# Altium schematic sheet IO_PWR.SchDoc(IO_PWR)
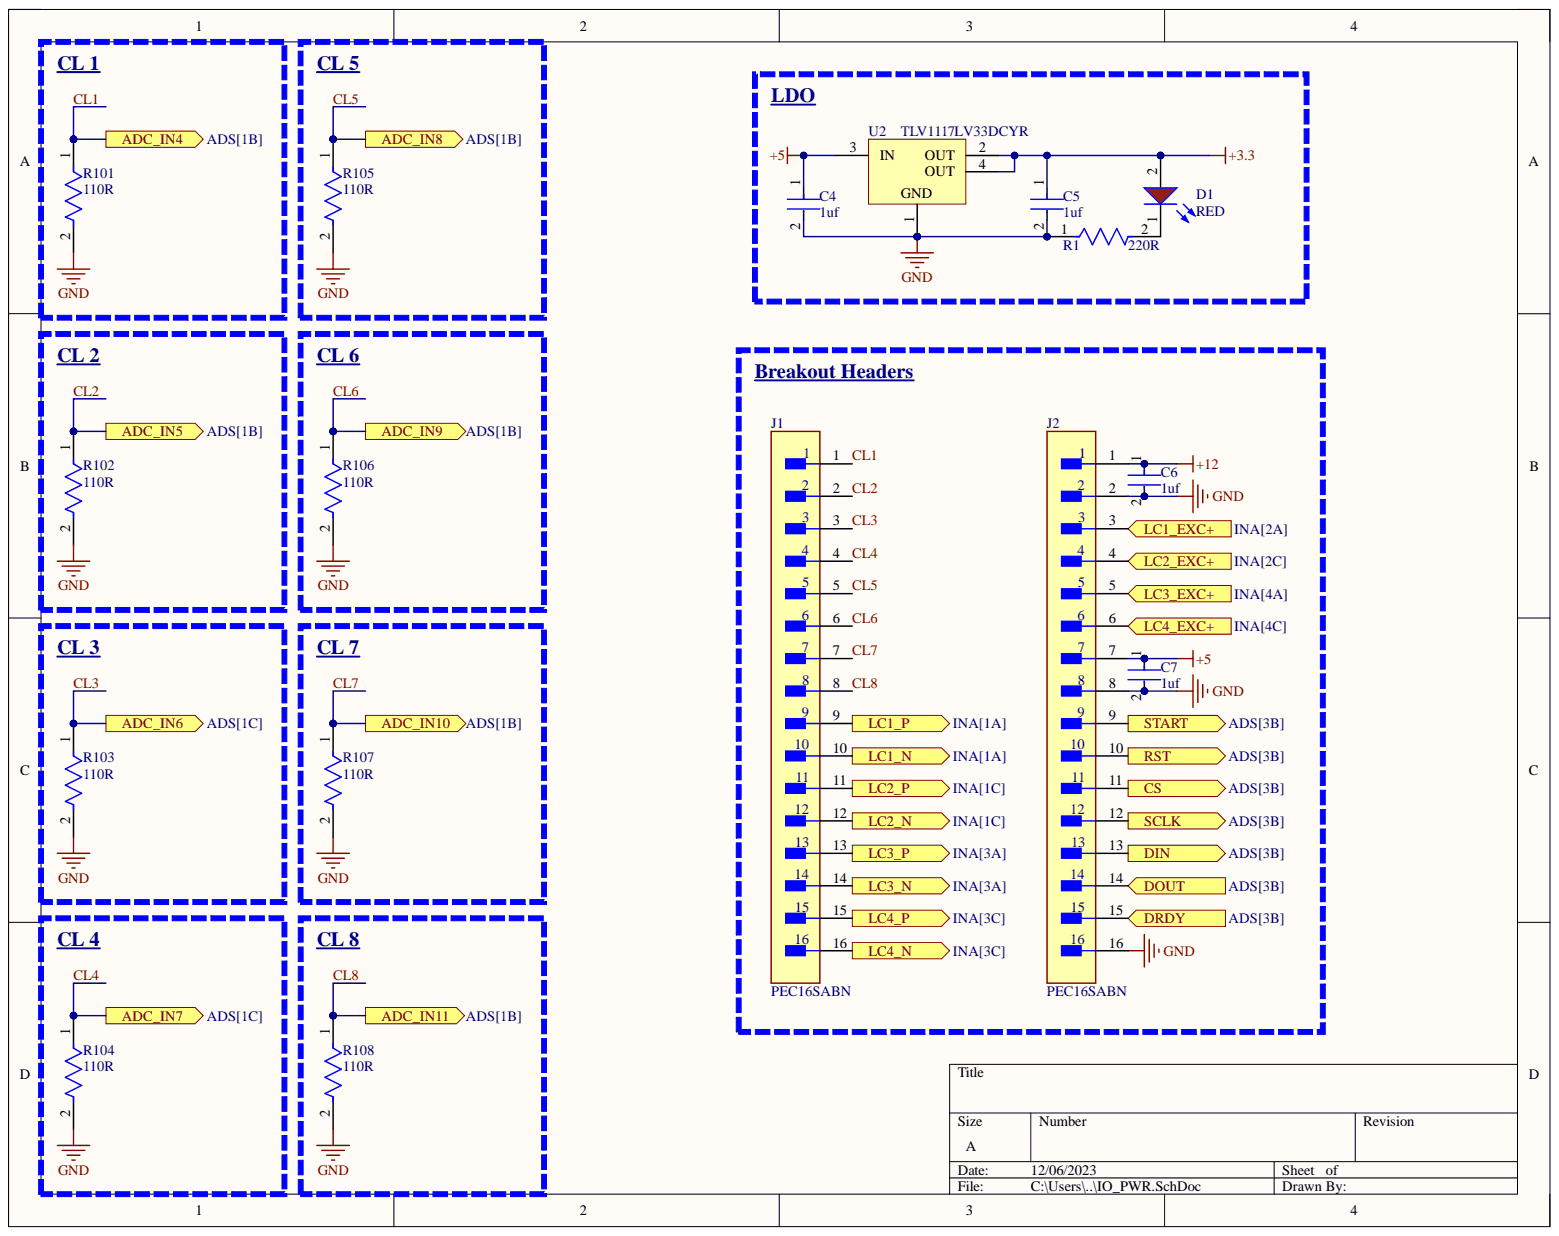
[diagram p0: IO_PWR.SchDoc]

## Parts
C4  1uf  [FP-1206-L_3_2_0_2-W_1_6_0-IPC_A]
C5  1uf  [FP-1206-L_3_2_0_2-W_1_6_0-IPC_A]
C6  1uf  [FP-1206-L_3_2_0_2-W_1_6_0-IPC_A]
C7  1uf  [FP-1206-L_3_2_0_2-W_1_6_0-IPC_A]
D1  RED  [WL-SMCW_0603_150060xx75000]
J1  PEC16SABN  [FP-PEC16SABN-MFG]
J2  PEC16SABN  [FP-PEC16SABN-MFG]
R1  220R  [FP-TFCR0603-IPC_A]
R101  110R  [FP-TFCR0603-IPC_A]
R102  110R  [FP-TFCR0603-IPC_A]
R103  110R  [FP-TFCR0603-IPC_A]
R104  110R  [FP-TFCR0603-IPC_A]
R105  110R  [FP-TFCR0603-IPC_A]
R106  110R  [FP-TFCR0603-IPC_A]
R107  110R  [FP-TFCR0603-IPC_A]
R108  110R  [FP-TFCR0603-IPC_A]
U2  TLV1117LV33DCYR  [DCY0004A_N]

## Ports
ADC_IN4, ADC_IN5, ADC_IN6, ADC_IN7, ADC_IN8, ADC_IN9, ADC_IN10, ADC_IN11, CS, DIN, DOUT, DRDY, LC1_EXC+, LC1_N, LC1_P, LC2_EXC+, LC2_N, LC2_P, LC3_EXC+, LC3_N, LC3_P, LC4_EXC+, LC4_N, LC4_P, RST, SCLK, START

## Nets
+3.3: C5.1 D1.2 U2.2(OUT) U2.4(OUT)
+5: C4.1 C7.1 J2.7 U2.3(IN)
+12: C6.1 J2.1
GND: C4.2 C5.2 C6.2 C7.2 J2.2 J2.8 J2.16 R1.1 R101.2 R102.2 R103.2 R104.2 R105.2 R106.2 R107.2 R108.2 U2.1
CL1: J1.1 R101.1 ; port ADC_IN4
CL2: J1.2 R102.1 ; port ADC_IN5
CL3: J1.3 R103.1 ; port ADC_IN6
CL4: J1.4 R104.1 ; port ADC_IN7
CL5: J1.5 R105.1 ; port ADC_IN8
CL6: J1.6 R106.1 ; port ADC_IN9
CL7: J1.7 R107.1 ; port ADC_IN10
CL8: J1.8 R108.1 ; port ADC_IN11
CS: J2.11 ; port CS
DIN: J2.13 ; port DIN
DOUT: J2.14 ; port DOUT
DRDY: J2.15 ; port DRDY
LC1_EXC+: J2.3 ; port LC1_EXC+
LC1_N: J1.10 ; port LC1_N
LC1_P: J1.9 ; port LC1_P
LC2_EXC+: J2.4 ; port LC2_EXC+
LC2_N: J1.12 ; port LC2_N
LC2_P: J1.11 ; port LC2_P
LC3_EXC+: J2.5 ; port LC3_EXC+
LC3_N: J1.14 ; port LC3_N
LC3_P: J1.13 ; port LC3_P
LC4_EXC+: J2.6 ; port LC4_EXC+
LC4_N: J1.16 ; port LC4_N
LC4_P: J1.15 ; port LC4_P
RST: J2.10 ; port RST
SCLK: J2.12 ; port SCLK
START: J2.9 ; port START
NetD1_1: D1.1 R1.2

## Library data of the parts
C4-C7 = CMP-04427-011252-1: Automotive Ceramic Capacitor, 1206, 1uF, 10%, X7R, 15%, 25V; Capacitance: 1uF; Case Code (Imperial): 1206; Case Code (Metric): 3216; Case/Package: 1206; Component Kind: Standard; Construction: Flat; Depth: 1.6mm; Dielectric: X7R; Features: Soft Termination; Height: 1.4mm; Length: 3.2mm; Library Name: Altium Content Vault; Max Operating Temperature: 125°C; Min Operating Temperature: -55°C; Mount: Surface Mount; Package Quantity: 2000; Packaging: Tape and Reel; Radiation Hardening: No; Ratings: AEC-Q200; RoHS Compliant: Yes; Series: FLEXITERM®; Termination: SMD/SMT; Thickness: 0.07inch; Tolerance: 10%; Voltage Rating: 25V; Voltage Rating (DC): 25V; Width: 1.6mm
D1 = CMP-1426-00001-3: NexarPartId: 29717776; Dominant Wavelength: 625nm; Forward Current: 100mA; Forward Voltage: 2V; Lens Style: Clear, Rectangular; Luminous Intensity: 250mcd; Max Junction Temperature (Tj): 95°C; Max Reverse Voltage (DC): 5V; Peak Wavelength: 630nm; Power Dissipation: 72mW; Test Current: 20mA; Viewing Angle: 140°; Case Code (Imperial): 0603; Case Code (Metric): 1608; Case/Package: 0603; Color: Red; Component Kind: Standard; Height: 700µm; Length: 1.6mm; Library Name: Altium Content Vault; Max Operating Temperature: 85°C; Min Operating Temperature: -40°C; Mount: Surface Mount; Packaging: Tape and Reel; REACH SVHC: Unknown; Reverse Voltage: 5V; RoHS: Compliant; Wavelength: 625nm; Width: 800µm
J1 J2 = CMP-25680-000075-1: CONN HEADER VERT 16POS 2.54MM; Connector Type: Male Pin; Gender: Male; Number of Contacts: 16; Pitch: 0.1inch; Color: Black; Component Kind: Standard; Contact Material: Bronze; Contact Mating Length: 0.23inch; Contact Plating: Tin; Contact Type: Male Pin; Height: 2.54mm; Housing Color: Black; Housing Material: Plastic; Lead Free: Lead Free; Length: 40.6mm; Library Name: Altium Content Vault; Mount: Through Hole; Number of Positions: 16; Number of Rows: 1; Package Quantity: 1; Packaging: Bulk; RoHS Compliant: Yes; Termination: Solder; Width: 2.54mm
R1 R101-R108 = CMP-250906-284725-1: RES Thin Film,  500Ω, 0.1%, 0.1W, 25ppm/°C, 0603; Component Kind: Standard; Library Name: Altium Content Vault; RoHS Compliant: Yes
U2 = CMP-0395-00503-2: Single Output LDO, 1 A, Fixed 3.3 V Output, 2 to 5.5 V Input, 4-pin SOT-223 (DCY), -40 to 125 degC, Green (RoHS & no Sb/Br); Manufacturer: Texas Instruments; Accuracy(%): 2; Fixed Output Options(V): 3.3; Iout(Max)(A): 1; Iq(Typ)(mA): 0.05; SubFamily: Single Channel LDO; Vdo(Typ)(mV): 1200; Vin(Max)(V): 5.5; Code_JEDEC: TO-261; Component Kind: Standard; ComponentLink1Description: Manufacturer URL; ComponentLink1URL: http://www.ti.com/; ComponentLink2Description: Datasheet; ComponentLink2URL: http://www.ti.com/general/docs/lit/getliterature.tsp?genericPartNumber=TLV1117LV33&fileType=pdf; ComponentLink3Description: Package Specification; ComponentLink3URL: http://www.ti.com/litv/pdf/mpds094a; DatasheetVersion: SBVS160A; Eco-Plan: Green (RoHS & no Sb/Br); Library Name: Altium Content Vault; Mounting Technology: Surface Mount; PackageDescription: 4-Pin Plastic Small Outline, Body 3.5 x 6.5 mm, Pitch 2.3 mm; PackageReference: DCY0004A; PackageVersion: 4202506/B; PartNumber: TLV1117LV33DCYR; Vin(Min)(V): 2; Vout Adj(Max)(V): 3.3; Vout Adj(Min)(V): 3.3
